# Altium schematic sheet Sheet1.SchDoc(Sheet1)
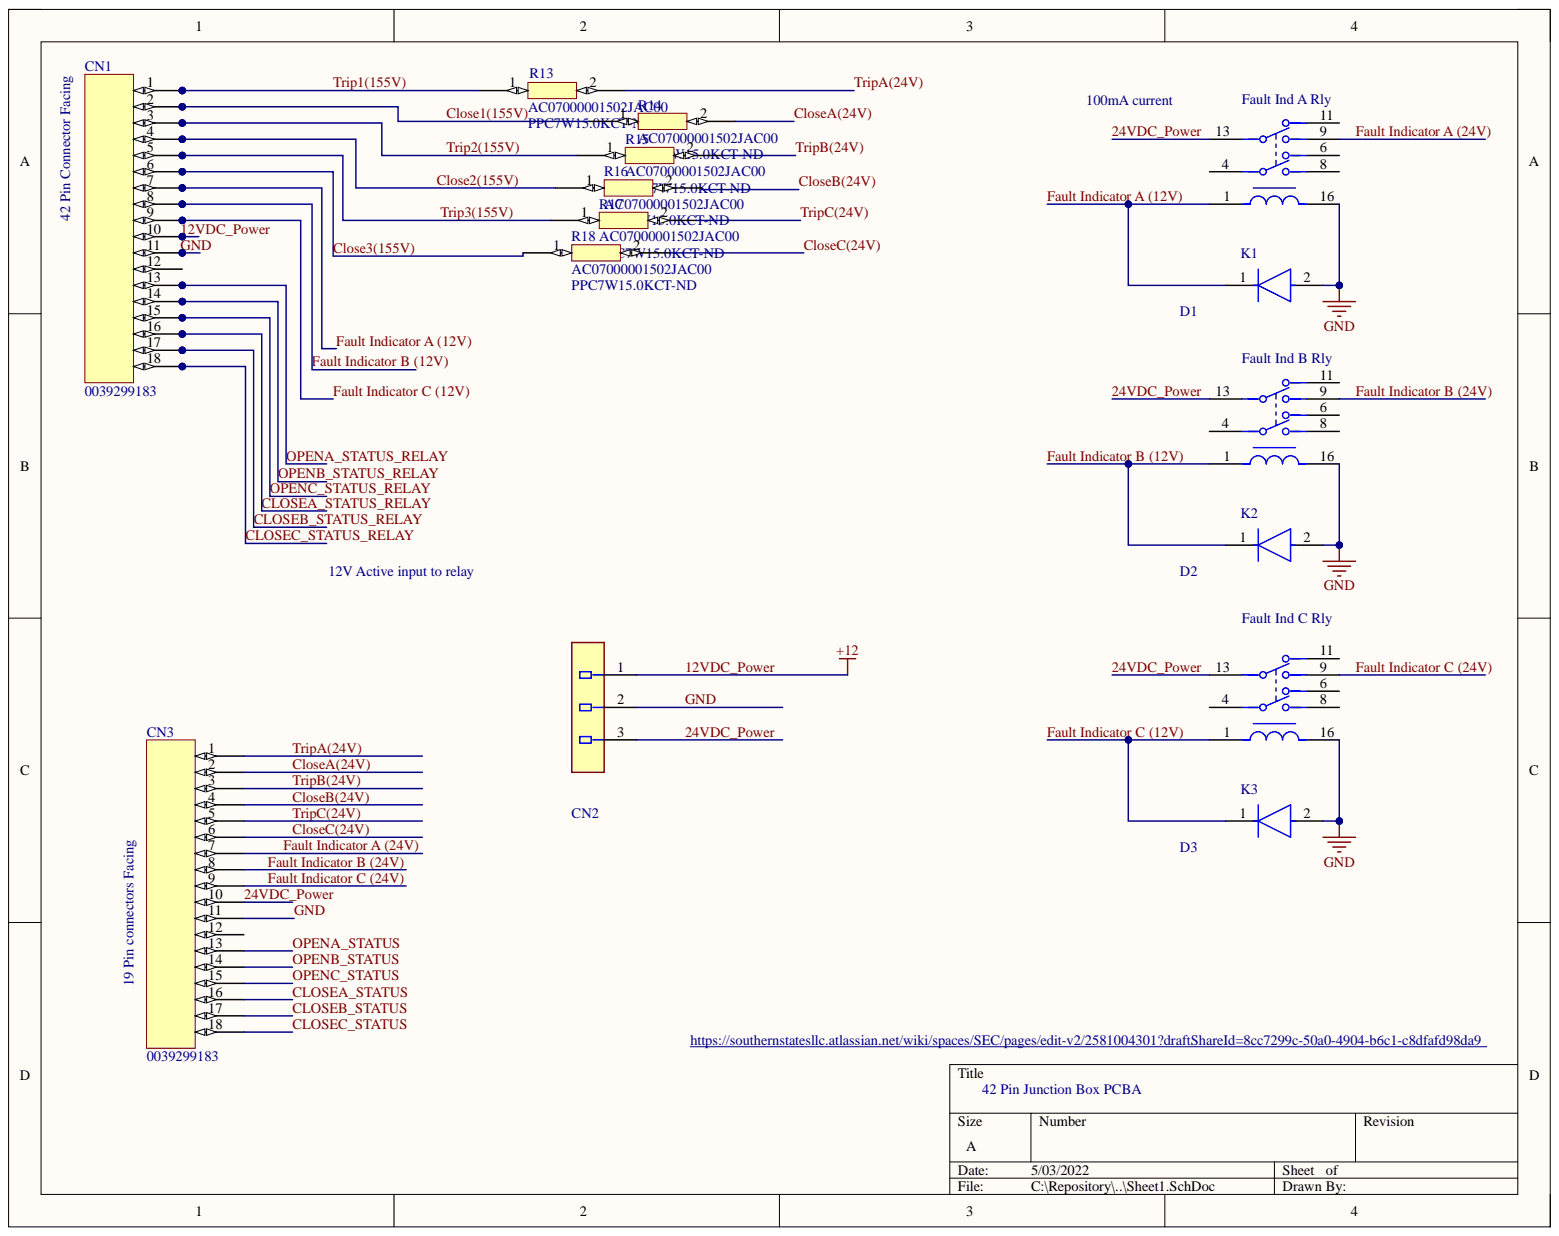
[diagram p0: Sheet1.SchDoc]

## Parts
CN1  0039299183  [0039299183]
CN2  15-31-1036  [Molex_15-31-1036_0]  (value not drawn)
CN3  0039299183  [0039299183]
D1  S1A-13-F  [Micro_Commercial_DO-214AC_MFG]  (value not drawn)
D2  S1A-13-F  [Micro_Commercial_DO-214AC_MFG]  (value not drawn)
D3  S1A-13-F  [Micro_Commercial_DO-214AC_MFG]  (value not drawn)
K1  DS2Y-S-DC24V  [Panasonic_DS2Y-S-DC24V_0]  (value not drawn)
K2  DS2Y-S-DC24V  [Panasonic_DS2Y-S-DC24V_0]  (value not drawn)
K3  DS2Y-S-DC24V  [Panasonic_DS2Y-S-DC24V_0]  (value not drawn)
R13  AC07000001502JAC00  [AC07000001502JAC00]
R14  AC07000001502JAC00  Supplier Part Number 1: PPC7W15.0KCT-ND  [AC07000001502JAC00]
R15  AC07000001502JAC00  Supplier Part Number 1: PPC7W15.0KCT-ND  [AC07000001502JAC00]
R16  AC07000001502JAC00  Supplier Part Number 1: PPC7W15.0KCT-ND  [AC07000001502JAC00]
R17  AC07000001502JAC00  Supplier Part Number 1: PPC7W15.0KCT-ND  [AC07000001502JAC00]
R18  AC07000001502JAC00  Supplier Part Number 1: PPC7W15.0KCT-ND  [AC07000001502JAC00]

## Nets
12VDC_Power: CN1.10 CN2.1
24VDC_Power: CN2.3 CN3.10 K1.13 K2.13 K3.13
Close1(155V): CN1.2 R14.1
Close2(155V): CN1.4 R16.1
Close3(155V): CN1.6 R18.1
CloseA(24V): CN3.2 R14.2
CLOSEA_STATUS: CN3.16
CLOSEA_STATUS_RELAY: CN1.16
CloseB(24V): CN3.4 R16.2
CLOSEB_STATUS: CN3.17
CLOSEB_STATUS_RELAY: CN1.17
CloseC(24V): CN3.6 R18.2
CLOSEC_STATUS: CN3.18
CLOSEC_STATUS_RELAY: CN1.18
Fault Indicator A (12V): CN1.7 D1.1 K1.1
Fault Indicator A (24V): CN3.7 K1.9
Fault Indicator B (12V): CN1.8 D2.1 K2.1
Fault Indicator B (24V): CN3.8 K2.9
Fault Indicator C (12V): CN1.9 D3.1 K3.1
Fault Indicator C (24V): CN3.9 K3.9
GND: CN1.11 CN2.2 CN3.11 D1.2 D2.2 D3.2 K1.16 K2.16 K3.16
OPENA_STATUS: CN3.13
OPENA_STATUS_RELAY: CN1.13
OPENB_STATUS: CN3.14
OPENB_STATUS_RELAY: CN1.14
OPENC_STATUS: CN3.15
OPENC_STATUS_RELAY: CN1.15
Trip1(155V): CN1.1 R13.1
Trip2(155V): CN1.3 R15.1
Trip3(155V): CN1.5 R17.1
TripA(24V): CN3.1 R13.2
TripB(24V): CN3.3 R15.2
TripC(24V): CN3.5 R17.2

Not connected: CN1 12; CN3 12; K1 4 6 8 11; K2 4 6 8 11; K3 4 6 8 11

## Library data of the parts
CN1 CN3 = 0039299183 - 18 pin molex mini fit jr 5566: Connector Header Through Hole 18 position 0.165" (4.20mm); Component Kind: Standard; Library Name: 42PinJunctionBox.SchLib
D1-D3 = FM2000GP: Component Kind: Standard; Library Name: Diode_Micro_Commercial_FM2000GP_eec.SchLib
R13-R18 = AC07000001502JAC00: Manufacturer: Vishay BCcomponents; Max Power Dissipation: 7W; Resistance: 15kR; Resistance Tolerance: 5%; Temperature Coefficient: 180ppm/°C; Voltage Rating: 324V; Case/Package: Axial; Component Kind: Standard; ComponentLink1Description: http://www.vishay.com/docs/28730/acseries.pdf?src-supplier=Avnet; ComponentLink1URL: http://www.vishay.com/docs/28730/acseries.pdf?src-supplier=Avnet; ComponentLink2Description: http://www.vishay.com/docs/28730/acseries.pdf?src-supplier=Avnet+Europe; ComponentLink2URL: http://www.vishay.com/docs/28730/acseries.pdf?src-supplier=Avnet+Europe; ComponentLink3Description: http://www.vishay.com/docs/28730/acac-at.pdf?src-supplier=Digi-Key; ComponentLink3URL: http://www.vishay.com/docs/28730/acac-at.pdf?src-supplier=Digi-Key; Contact Plating: Tin; Diameter: 7.5mm; Features: Flame Retardant Coating; Length: 1.024inch; Manufacturer Part Number: AC07000001502JAC00; Max Operating Temperature: 275°C; Min Operating Temperature: -40°C; Mount: Through Hole; Number of Pins: 2; Number of Terminations: 2; Package Quantity: 1; Packaging: Tape and Reel; Power Rating: 7W; Pricing 1: 1=2.26, 10=2.002, 25=1.8564, 50=1.7472, 100=1.5288, 250=1.3104 (USD); Radiation Hardening: No; Resistor Type: Wirewound; RoHS Compliant: Yes; Series: AC; Stock 1: 0; Supplier 1: Digi-Key; Termination: Axial; Tolerance: 5%; Voltage Rating (DC): 324V; Width: 7.49mm; Library Name: 42PinJunctionBox.SchLib
  R13: Supplier Part Number 1: PPC7W15.0KCT-ND
CN2 = 15-31-1036: Component Kind: Standard; Library Name: ASD_MASTER.SCHLIB
K1-K3 = DS2Y-S-DC24V: Component Kind: Standard; Library Name: ASD_MASTER.SCHLIB
